# Altium schematic sheet MainPower.SchDoc
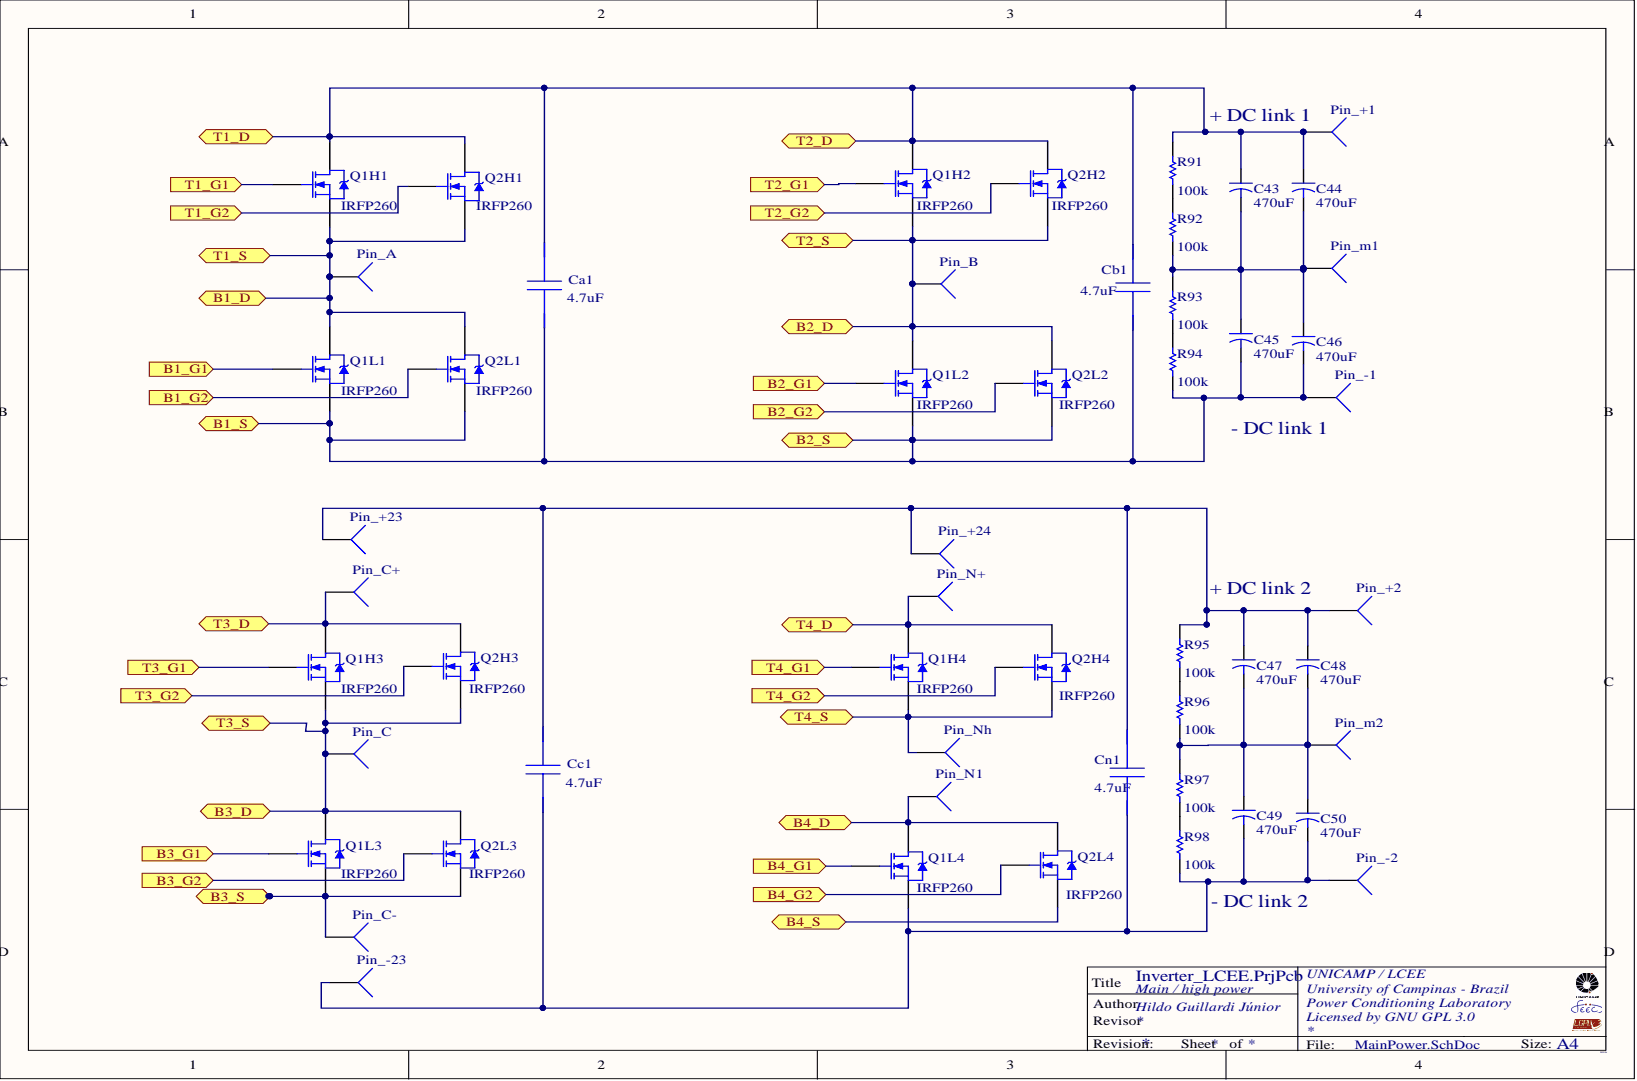
[diagram p0: MainPower.SchDoc]

## Parts
C43  value 470uF  [Capacitor Eletrolitico - duplicate]
C44  value 470uF  [Capacitor Eletrolitico - duplicate]
C45  value 470uF  [Capacitor Eletrolitico - duplicate]
C46  value 470uF  [Capacitor Eletrolitico - duplicate]
C47  value 470uF  [Capacitor Eletrolitico - duplicate]
C48  value 470uF  [Capacitor Eletrolitico - duplicate]
C49  value 470uF  [Capacitor Eletrolitico - duplicate]
C50  value 470uF  [Capacitor Eletrolitico - duplicate]
Ca1  value 4.7uF  [CApacitor SMD - 22uF]
Cb1  value 4.7uF  [CApacitor SMD - 22uF]
Cc1  value 4.7uF  [CApacitor SMD - 22uF]
Cn1  value 4.7uF  [CApacitor SMD - 22uF]
Pin_+1  (no value)  [Power conector]
Pin_+2  (no value)  [Power conector]
Pin_+23  (no value)  [Power conector]
Pin_+24  (no value)  [Power conector]
Pin_-1  (no value)  [Power conector]
Pin_-2  (no value)  [Power conector]
Pin_-23  (no value)  [Power conector]
Pin_A  (no value)  [Power conector]
Pin_B  (no value)  [Power conector]
Pin_C  (no value)
Pin_C+  (no value)  [Power conector]
Pin_C-  (no value)  [Power conector]
Pin_m1  (no value)  [Power conector]
Pin_m2  (no value)  [Power conector]
Pin_N1  (no value)  [Power conector]
Pin_N+  (no value)  [Power conector]
Pin_Nh  (no value)  [Power conector]
Q1H1  value IRFP260  [TO-247AC]
Q1H2  value IRFP260  [TO-247AC]
Q1H3  value IRFP260  [TO-247AC]
Q1H4  value IRFP260  [TO-247AC]
Q1L1  value IRFP260  [TO-247AC]
Q1L2  value IRFP260  [TO-247AC]
Q1L3  value IRFP260  [TO-247AC]
Q1L4  value IRFP260  [TO-247AC]
Q2H1  value IRFP260  [TO-247AC]
Q2H2  value IRFP260  [TO-247AC]
Q2H3  value IRFP260  [TO-247AC]
Q2H4  value IRFP260  [TO-247AC]
Q2L1  value IRFP260  [TO-247AC]
Q2L2  value IRFP260  [TO-247AC]
Q2L3  value IRFP260  [TO-247AC]
Q2L4  value IRFP260  [TO-247AC]
R91  value 100k  [1210_W]
R92  value 100k  [1210_W]
R93  value 100k  [1210_W]
R94  value 100k  [1210_W]
R95  value 100k  [1210_W]
R96  value 100k  [1210_W]
R97  value 100k  [1210_W]
R98  value 100k  [1210_W]

## Ports
B1_D, B1_G1, B1_G2, B1_S, B2_D, B2_G1, B2_G2, B2_S, B3_D, B3_G1, B3_G2, B3_S, B4_D, B4_G1, B4_G2, B4_S, T1_D, T1_G1, T1_G2, T1_S, T2_D, T2_G1, T2_G2, T2_S, T3_D, T3_G1, T3_G2, T3_S, T4_D, T4_G1, T4_G2, T4_S

## Nets
B1_D: Pin_A.1 Q1H1.3 Q1L1.2 Q2H1.3 Q2L1.2 ; port B1_D, port T1_S
B1_G1: Q1L1.1 ; port B1_G1
B1_G2: Q2L1.1 ; port B1_G2
B1_S: C45.2 C46.2 Ca1.2 Cb1.2 Pin_-1.1 Q1L1.3 Q1L2.3 Q2L1.3 Q2L2.3 R94.2 ; port B1_S, port B2_S
B2_D: Pin_B.1 Q1H2.3 Q1L2.2 Q2H2.3 Q2L2.2 ; port B2_D, port T2_S
B2_G1: Q1L2.1 ; port B2_G1
B2_G2: Q2L2.1 ; port B2_G2
B3_D: Pin_C.1 Q1H3.3 Q1L3.2 Q2H3.3 Q2L3.2 ; port B3_D, port T3_S
B3_G1: Q1L3.1 ; port B3_G1
B3_G2: Q2L3.1 ; port B3_G2
B3_S: Pin_C-.1 Q1L3.3 Q2L3.3 ; port B3_S
B4_D: Pin_N1.1 Q2L4.2 ; port B4_D
B4_G1: Q1L4.1 ; port B4_G1
B4_G2: Q2L4.1 ; port B4_G2
B4_S: Q2L4.3 ; port B4_S
T1_D: C43.1 C44.1 Ca1.1 Cb1.1 Pin_+1.1 Q1H1.2 Q1H2.2 Q2H1.2 Q2H2.2 R91.1 ; port T1_D, port T2_D
T1_G1: Q1H1.1 ; port T1_G1
T1_G2: Q2H1.1 ; port T1_G2
T2_G1: Q1H2.1 ; port T2_G1
T2_G2: Q2H2.1 ; port T2_G2
T3_D: Pin_C+.1 Q2H3.2 ; port T3_D
T3_G1: Q1H3.1 ; port T3_G1
T3_G2: Q2H3.1 ; port T3_G2
T4_D: Pin_N+.1 Q1H4.2 Q2H4.2 ; port T4_D
T4_G1: Q1H4.1 ; port T4_G1
T4_G2: Q2H4.1 ; port T4_G2
T4_S: Pin_Nh.1 Q1H4.3 Q2H4.3 ; port T4_S
NetC43_2: C43.2 C44.2 C45.1 C46.1 Pin_m1.1 R92.2 R93.1
NetC47_1: C47.1 C48.1 Cc1.1 Cn1.1 Pin_+2.1 Pin_+23.1 Pin_+24.1 R95.1
NetC47_2: C47.2 C48.2 C49.1 C50.1 Pin_m2.1 R96.2 R97.1
NetC49_2: C49.2 C50.2 Cc1.2 Cn1.2 Pin_-2.1 Pin_-23.1 Q1L4.3 R98.2
NetR91_2: R91.2 R92.1
NetR93_2: R93.2 R94.1
NetR95_2: R95.2 R96.1
NetR97_2: R97.2 R98.1

Not connected: Q1H3 2; Q1L4 2

## Library data of the parts
C43-C50 = Cap2: Capacitor; Component Kind: Standard; Library Name: Miscellaneous Devices.IntLib; PackageDescription: Capacitor; 2 Leads; PackageReference: CAPR5-4X5; Published: 23-Sep-2002; Signal Integrity: Cap; Simulation: CAP; Publisher: Altium Limited
Ca1 Cb1 Cc1 Cn1 = GRM21BC81E475KA12L: Manufacturer Name: MuRata; Component Kind: Standard; Library Name: TI WEBENCH; Manufacturer Part Number: GRM21BC81E475KA12L; PackageReference: GRM21BC81E475KA12L
Pin_+1 Pin_+2 Pin_+23 Pin_+24 Pin_-1 Pin_-2 Pin_-23 Pin_A Pin_B Pin_C Pin_C+ Pin_C- Pin_m1 Pin_m2 Pin_N1 Pin_N+ Pin_Nh = Socket: Component Kind: Standard; Description: Socket; LatestRevisionDate: 17-Jul-2002; LatestRevisionNote: Re-released for DXP Platform.; Library Name: Miscellaneous Connectors.IntLib; Signal Integrity: Connector; Publisher: Altium Limited
Q1H1-Q1H4 Q1L1-Q1L4 Q2H1-Q2H4 Q2L1-Q2L4 = IRFP260: HEXFET Power MOSFET; Note: Pin 2 is electrically connected to the Heatsink (4).; Code_JEDEC: TO-247-AC; Component Kind: Standard; ComponentLink1Description: Manufacturer Link; ComponentLink1URL: http://www.irf.com/; ComponentLink2Description: Datasheet; ComponentLink2URL: http://www.irf.com/product-info/datasheets/data/irfp260.pdf; DatasheetVersion: PD-9.755; LatestRevisionDate: 17-Jul-2002; LatestRevisionNote: Re-released for DXP Platform.; Library Name: IR Discrete MOSFET - Power.IntLib; PackageDescription: SIP with Mounting Hole; 3 Leads; Body 20 x 15.6 x 5 mm (typ); Pitch 5.45 mm; PackageReference: TO-247AC; PackageVersion: Aug-1997; Published: 8-Jun-2000; Simulation: IRFP260; Publisher: Altium Limited
R91-R98 = Res_0805: Resistor; Manufacturer: Generic Components; Class: Passive; Component Kind: Standard; Published: 08/06/2000; Signal Integrity: Res1; Simulation: RESISTOR; SubClass: Resistor; Library Name: Inversor_LCEE.SchLib; Publisher: Altium Hobart Technology Centre
